# Altium schematic sheet MCU, Radios, and Sensors,SchDoc.SchDoc
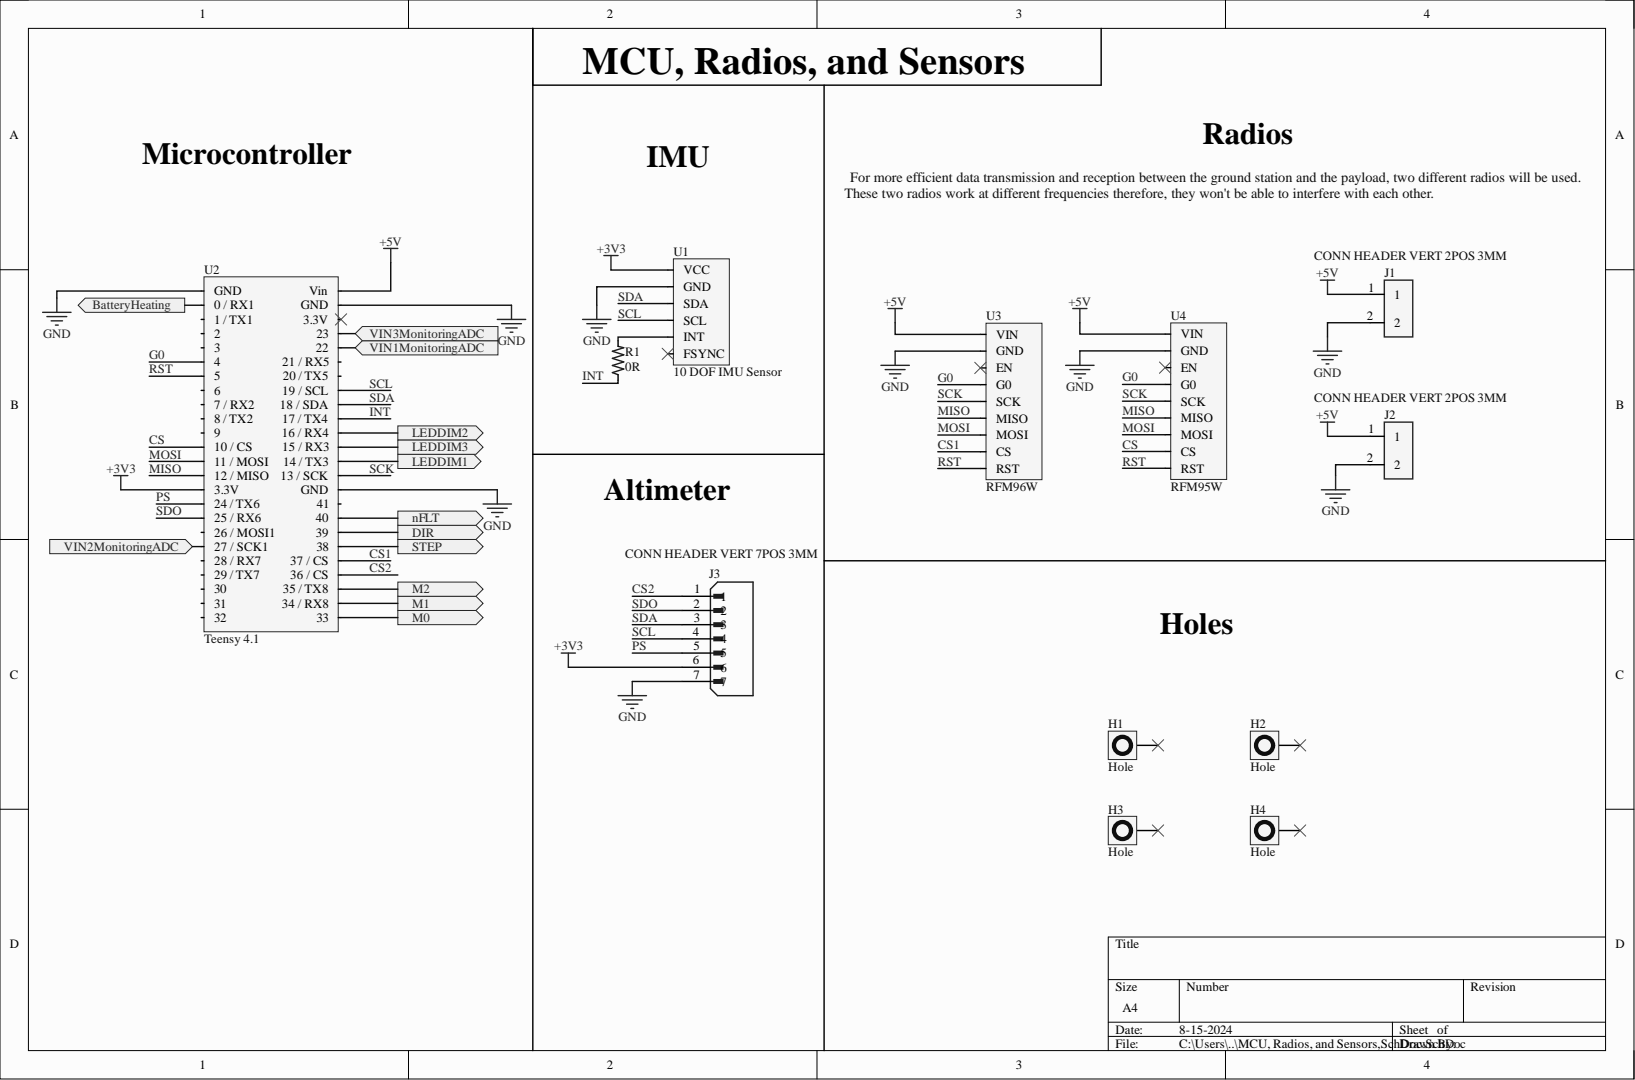
[diagram p0: MCU, Radios, and Sensors,SchDoc.SchDoc]

## Parts
H1  Hole  [M3 Holes]
H2  Hole  [M3 Holes]
H3  Hole  [M3 Holes]
H4  Hole  [M3 Holes]
J1  CONN HEADER VERT 2POS 3MM  [CONN HEADER VERT 2POS 3MM]
J2  CONN HEADER VERT 2POS 3MM  [CONN HEADER VERT 2POS 3MM]
J3  CONN HEADER VERT 7POS 3MM  [MOLEX_436500728]
R1  0R  [res 0805]
U1  10 DOF IMU Sensor  [10 DOF IMU Sensor]
U2  Teensy 4.1  [Teensy 4.1]
U3  RFM96W  [RFM95W]
U4  RFM95W  [RFM95W]

## Ports
BatteryHeating, DIR, LEDDIM1, LEDDIM2, LEDDIM3, M0, M1, M2, nFLT, STEP, VIN1MonitoringADC, VIN2MonitoringADC, VIN3MonitoringADC

## Nets
+3V3: J3.6 U1.1(VCC) U2.15(3.3V)
+5V: J1.1 J2.1 U2.48(Vin) U3.1(VIN) U4.1(VIN)
GND: J1.2 J2.2 J3.7 U1.2(GND) U2.1(GND) U2.34(GND) U2.47(GND) U3.2(GND) U4.2(GND)
BatteryHeating: U2.2(0_/_RX1) ; port BatteryHeating
CS: U2.12(10_/_CS) U4.8(CS)
CS1: U2.29(37_/_CS) U3.8(CS)
CS2: J3.1 U2.28(36_/_CS)
DIR: U2.31 ; port DIR
G0: U2.6 U3.4(G0) U4.4(G0)
INT: R1.1 U2.39(17_/_TX4)
LEDDIM1: U2.36(14_/_TX3) ; port LEDDIM1
LEDDIM2: U2.38(16_/_RX4) ; port LEDDIM2
LEDDIM3: U2.37(15_/_RX3) ; port LEDDIM3
M0: U2.25 ; port M0
M1: U2.26(34_/_RX8) ; port M1
M2: U2.27(35_/_TX8) ; port M2
MISO: U2.14(12_/_MISO) U3.6(MISO) U4.6(MISO)
MOSI: U2.13(11_/_MOSI) U3.7(MOSI) U4.7(MOSI)
nFLT: U2.32 ; port nFLT
PS: J3.5 U2.16(24_/_TX6)
RST: U2.7 U3.9(RST) U4.9(RST)
SCK: U2.35(13_/_SCK) U3.5(SCK) U4.5(SCK)
SCL: J3.4 U1.4(SCL) U2.41(19_/_SCL)
SDA: J3.3 U1.3(SDA) U2.40(18_/_SDA)
SDO: J3.2 U2.17(25_/_RX6)
STEP: U2.30 ; port STEP
VIN1MonitoringADC: U2.44 ; port VIN1MonitoringADC
VIN2MonitoringADC: U2.19(27_/_SCK1) ; port VIN2MonitoringADC
VIN3MonitoringADC: U2.45 ; port VIN3MonitoringADC
NetR1_2: R1.2 U1.5(INT)

Not connected: H1 1; H2 1; H3 1; H4 1; U1 6(FSYNC); U2 3(1_/_TX1) 4 5 8 9(7_/_RX2) 10(8_/_TX2) 11 18(26_/_MOSI1) 20(28_/_RX7) 21(29_/_TX7) 22 23 24 33 42(20_/_TX5) 43(21_/_RX5) 46(3.3V); U3 3(EN); U4 3(EN)

## Library data of the parts
J1 J2 = 0436500228: Rectangular Power Connector, 2 Contact(s), Female, Solder Terminal; Manufacturer: Molex; Manufacturer Part Number: 43650-0228; Manufacturer Series: 43650; Board Mounting Option: PEG; Body Breadth: 0.219inch; Body Depth: 0.39inch; Body Length: 0.38inch; Body/Shell Style: RECEPTACLE; Connector Type: Power,Rectangular; Contact Resistance: 10mR; Contact Style: SQ PIN-SKT; Dielectric Withstanding Voltage (AC): 1.5kV; DIN Conformance: No; Durability: 30Cycles; Insertion Force-Max: 8.0064N; Insulation Resistance: 1GR; Mating Contact Pitch: 0.118inch; Mating Information: MULTIPLE MATING PARTS AVAILABLE; Mounting Option 1: POLARIZED LCK; Mounting Option 2: LOCKING; MP: 0436500200; Number Of Connectors: 1; Number of Contacts: 2; Option: General Purpose; Plating Thickness: 15uin; Polarization Key: POLARIZED HOUSING; Rated Current (Signal): 5A; Reference Standard: UL; Reliability: COMMERCIAL; Withdrawl Force-Min: 3.614N; Availability: Unavailable; Category: Headers and Wire Housings; Component Kind: Standard; ComponentLink1Description: https://datasheet.ciiva.com:443/pdfs/VipMasterIC/IC/MOLE/MOLES07498/MOLES07498-1.pdf?src-supplier=IHS+Markit; ComponentLink1URL: https://datasheet.ciiva.com:443/pdfs/VipMasterIC/IC/MOLE/MOLES07498/MOLES07498-1.pdf?src-supplier=IHS+Markit; ComponentLink2Description: https://datasheet.ciiva.com/13550/0436500228-pcb-headers-148884-13550297.pdf?src-supplier=Mouser; ComponentLink2URL: https://datasheet.ciiva.com/13550/0436500228-pcb-headers-148884-13550297.pdf?src-supplier=Mouser; Contact Finish - Mating: AU; Contact Finish - Termination: TIN/LEAD OVER NICKEL; Contact Gender: Male; Contact Material: Brass; Contact Pattern: RECTANGULAR; Empty Shell: No; Filter Feature: No; Flammability Rating: UL94 V-0; IEC Conformance: No; Insulation Color: Black; Insulation Material: Polymer; Max Operating Temperature: 105°C; MF: Molex; MIL Conformance: No; Min Operating Temperature: -40°C; Mixed Contacts: No; Mounting Style: STRAIGHT; Mounting Technology: Board; Number Of PCB Rows: 1; Number of Rows Loaded: 1; Package: None; PCB Contact Pattern: RECTANGULAR; Price: None; Pricing: 1=0.78, 10=0.537, 50=0.537, 100=0.537, 1000=0.536, 10000=0.473 (USD); Reach SVHC Compliant: Yes; RoHS: Yes; RoHS Compliant: Yes; Shell Material: Polymer; SnapEDA_Link: https://www.snapeda.com/parts/0436500200/Molex/view-part/552556/?ref=snap; Stock: 12783; Supplier: Mouser; Supplier Part Number: 538-43650-0228; Terminal Length: 0.125inch; Terminal Pitch: 2.9972mm; Termination: Solder; Library Name: Payload schlib.SchLib
J3 = 436500728: Connector Header Through Hole 7 position 0.118 (3.00mm); MF: Molex; Check_prices: https://www.snapeda.com/parts/436500728/Molex/view-part/?ref=eda; Component Kind: Standard; Digi-Key_Part_Number: WM10682-ND; MP: 436500728; Package: None; SnapEDA_Link: https://www.snapeda.com/parts/436500728/Molex/view-part/?ref=snap; Library Name: Payload schlib.SchLib
R1 = Resistor 0805: MF: TE Connectivity; MP: 2-2176053-2; Power_Rating: .25 W; Resistance: .39; Tolerance: 1%; Availability: Unavailable; Component Kind: Standard; EU_RoHS_Compliance: Compliant with Exemptions; Package: 0805; Price: None; SnapEDA_Link: https://www.snapeda.com/parts/2-2176053-2/TE%20Connectivity/view-part/2214511/?ref=snap; Library Name: Payload schlib.SchLib
U1 = 10 DOF IMU Sensor: 10 DOF IMU Sensor, ICM20948 Onboard, Low Power, High Precision; Component Kind: Standard; Library Name: Payload schlib.SchLib
U2 = Teensy 4.1: iMXRT1062 Microcontroller Development Board 600MHz CPU 1GB RAM 8MB Flash; Manufacturer: SparkFun; Manufacturer Part Number: DEV-16771; Category: Embedded Processors and Controllers; Component Kind: Standard; ComponentLink1Description: https://media.digikey.com/pdf/Data%20Sheets/Sparkfun%20PDFs/DEV-16771_Web.pdf?src-supplier=Digikey; ComponentLink1URL: https://media.digikey.com/pdf/Data%20Sheets/Sparkfun%20PDFs/DEV-16771_Web.pdf?src-supplier=Digikey; Pricing: 1=37.2 (USD); RoHS: No; Stock: 2404; Supplier: Digikey; Supplier Part Number: 1568-DEV-16771-ND; Library Name: Payload schlib.SchLib
U3 = RFM96W: Banana Plug; Female to Multi-Stacking Banana Plug; Nickel Plated Brass; Manufacturer: Adafruit Industries; Manufacturer Part Number: 3072; Category: RF Semiconductors and Devices; Component Kind: Standard; ComponentLink1Description: https://learn.adafruit.com/downloads/pdf/adafruit-rfm69hcw-and-rfm96-rfm95-rfm98-lora-packet-padio-breakouts.pdf?src-supplier=Digikey; ComponentLink1URL: https://learn.adafruit.com/downloads/pdf/adafruit-rfm69hcw-and-rfm96-rfm95-rfm98-lora-packet-padio-breakouts.pdf?src-supplier=Digikey; Pricing: 1=19.95 (USD); RoHS: No; Stock: 109; Supplier: Digikey; Supplier Part Number: 1528-1667-ND; Library Name: Payload schlib.SchLib
U4 = RFM95W: Adafruit RFM95W LoRa Radio Transceiver Breakout - 868 or 915 MHz; Manufacturer: Adafruit Industries; Manufacturer Part Number: 3072; Category: RF Semiconductors and Devices; Component Kind: Standard; ComponentLink1Description: https://learn.adafruit.com/downloads/pdf/adafruit-rfm69hcw-and-rfm96-rfm95-rfm98-lora-packet-padio-breakouts.pdf?src-supplier=Digikey; ComponentLink1URL: https://learn.adafruit.com/downloads/pdf/adafruit-rfm69hcw-and-rfm96-rfm95-rfm98-lora-packet-padio-breakouts.pdf?src-supplier=Digikey; Pricing: 1=19.95 (USD); RoHS: No; Stock: 109; Supplier: Digikey; Supplier Part Number: 1528-1667-ND; Library Name: Payload schlib.SchLib
H1-H4 = Hole: Component Kind: Standard; Library Name: MRT SCH Library.SchLib
